# Altium schematic sheet grove_A9_Sensor.SchDoc(grove_A9_Sensor)
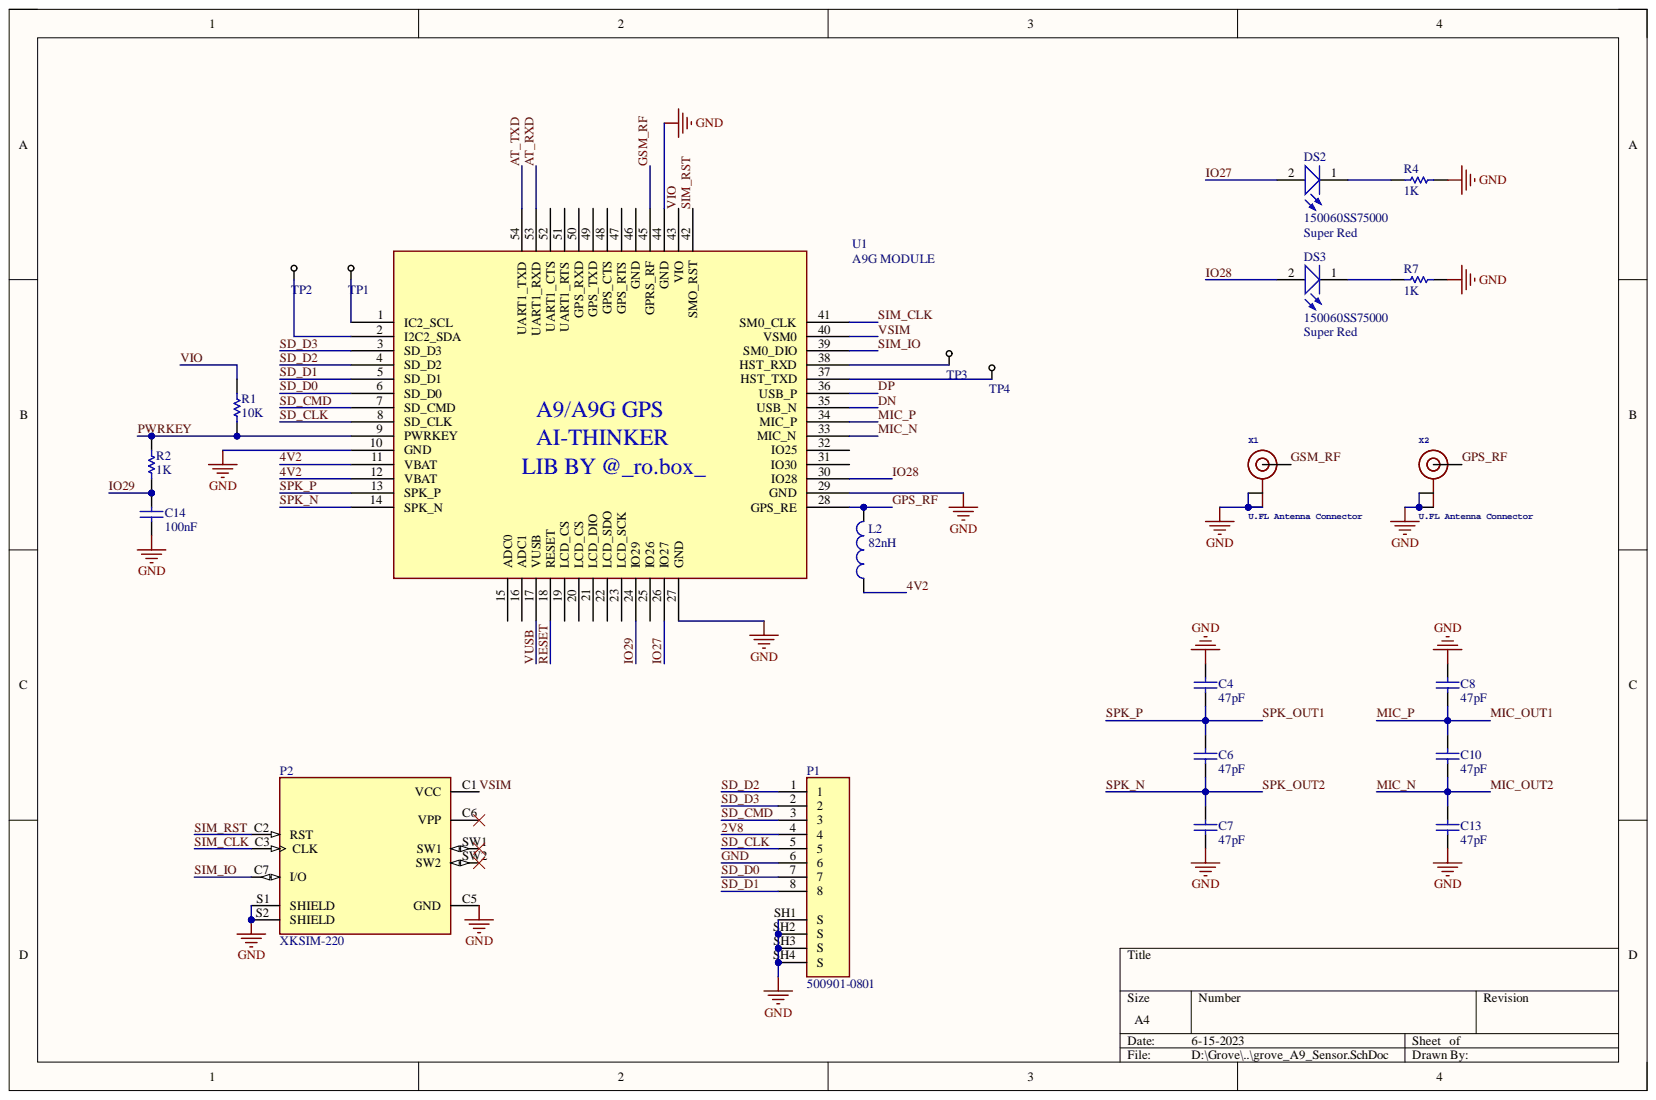
[diagram p0: grove_A9_Sensor.SchDoc]

## Parts
C4  47pF  [CAP0603]
C6  47pF  [CAP0603]
C7  47pF  [CAP0603]
C8  47pF  [CAP0603]
C10  47pF  [CAP0603]
C13  47pF  [CAP0603]
C14  100nF  [CAP0603]
DS2  150060SS75000  [WL-SMCW_0603]
DS3  150060SS75000  Emitting Color: Super Red  [WL-SMCW_0603]
L2  82nH  [IND0603]
P1  500901-0801  [MOLEX_500901-0801]
P2  XKSIM-220  [AMPHENOL_1010030682]
R1  10K  [RES0603]
R2  1K  [RES0603]
R4  1K  [RES0603]
R7  1K  [RES0603]
TP1  SCL  [Test Point SMD]  (value not drawn)
TP2  SDA  [Test Point SMD]  (value not drawn)
TP3  Test Point  [Test Point SMD]  (value not drawn)
TP4  Test Point  [Test Point SMD]  (value not drawn)
U1  A9G MODULE  [A9_FOOTPRINT]
X1  U.FL Antenna Connector  [U.FL]
X2  U.FL Antenna Connector  [U.FL]

## Nets
2V8: P1.4
4V2: L2.1 U1.11(VBAT) U1.12(VBAT)
AT_RXD: U1.53(UART1_RXD)
AT_TXD: U1.54(UART1_TXD)
DN: U1.35(USB_N)
DP: U1.36(USB_P)
GND: C4.2 C7.1 C8.2 C13.1 C14.1 P1.6 P1.SH1(S) P1.SH2(S) P1.SH3(S) P1.SH4(S) P2.C5(GND) P2.S1(SHIELD) P2.S2(SHIELD) R4.2 R7.2 U1.10(GND) U1.27(GND) U1.29(GND) U1.44(GND) X1.1 X1.2 X2.1 X2.2
GPS_RF: L2.2 U1.28(GPS_RE) X2.3
GSM_RF: U1.45(GPRS_RF) X1.3
IO27: DS2.2 U1.26(IO27)
IO28: DS3.2 U1.30(IO28)
IO29: C14.2 R2.1 U1.24(IO29)
MIC_N: C10.1 C13.2 U1.33(MIC_N)
MIC_OUT1: C8.1 C10.2 U1.34(MIC_P)
PWRKEY: R1.1 R2.2 U1.9(PWRKEY)
RESET: U1.18(RESET)
SD_CLK: P1.5 U1.8(SD_CLK)
SD_CMD: P1.3 U1.7(SD_CMD)
SD_D0: P1.7 U1.6(SD_D0)
SD_D1: P1.8 U1.5(SD_D1)
SD_D2: P1.1 U1.4(SD_D2)
SD_D3: P1.2 U1.3(SD_D3)
SIM_CLK: P2.C3(CLK) U1.41(SM0_CLK)
SIM_IO: P2.C7(I/O) U1.39(SM0_DIO)
SIM_RST: P2.C2(RST) U1.42(SMO_RST)
SPK_N: C6.1 C7.2 U1.14(SPK_N)
SPK_OUT1: C4.1 C6.2 U1.13(SPK_P)
VIO: R1.2 U1.43(VIO)
VSIM: P2.C1(VCC) U1.40(VSM0)
VUSB: U1.17(VUSB)
NetDS2_1: DS2.1 R4.1
NetDS3_1: DS3.1 R7.1
NetTP1_1: TP1.1 U1.1(IC2_SCL)
NetTP2_1: TP2.1 U1.2(I2C2_SDA)
NetTP3_1: TP3.1 U1.38(HST_RXD)
NetTP4_1: TP4.1 U1.37(HST_TXD)

Not connected: P2 C6(VPP) SW1 SW2; U1 15(ADC0) 16(ADC1) 19(LCD_CS) 20(LCD_CS) 21(LCD_DIO) 22(LCD_SDO) 23(LCD_SCK) 25(IO26) 31(IO30) 32(IO25) 46(GND) 47(GPS_RTS) 48(GPS_CTS) 49(GPS_TXD) 50(GPS_RXD) 51(UART1_RTS) 52(UART1_CTS)

## Library data of the parts
C4 C6-C8 C10 C13 = CAP SMD PACKAGE: TOTAL CAPACITOR SMD PACK; Desc.: CAP SMD TOTAL PACKAGES; Designer: Abbas Basereh; VALUE: 100nF; Component Kind: Standard; Data: 2017-3-10; Library Name: Altium.IntLib; Signal Integrity: CAP SMD
C14 = CAP SMD PACKAGE: CAPACITOR 0603; Desc.: CAP SMD TOTAL PACKAGES; Designer: Abbas Basereh; Component Kind: Standard; Data: 2017-3-10; Library Name: Altium.IntLib; Signal Integrity: CAP SMD; VALUE: 100nF
DS2 DS3 = 150060SS75000: WL-SMCW SMD Mono-color Chip LED Waterclear, size 0603, Super Red; Forward Voltage: 1.9 V; Peak Wavelength: 645 nm; Viewing Angle: 140°; Component Kind: Standard; ComponentLink1Description: Manufacturer; ComponentLink1URL: http://www.we-online.de; ComponentLink2Description: Datasheet; ComponentLink2URL: http://katalog.we-online.de/led/datasheet/150060SS75000.pdf; Library Name: LED SMT MonoColor.IntLib; Part Number: 150060SS75000; Published Date: Jan 2017; Size: 0603; Publisher: Wurth Elektronik
  DS2: Emitting Color: Super Red
L2 = INDUCTOR SMD PACKAGE: TOTAL INDUCTOR SMD PACK; Desc.: INDUCTOR  SMD TOTAL PACKAGES; Designer: Abbas Basereh; VALUE: 1u; Component Kind: Standard; Data: 2017-3-10; Library Name: Altium.IntLib; Signal Integrity: IND SMD
P1 = 500901-0801: 8 Position Card Connector Secure Digital - microSDâ„¢ Surface Mount, Right Angle Gold; MF: Molex; Availability: Unavailable; Component Kind: Standard; Library Name: 500901-0801.IntLib; MP: 500901-0801; Package: None; Price: None; SnapEDA_Link: https://www.snapeda.com/parts/500901-0801/Molex/view-part/48884/?ref=snap
P2 = 1010030682: 8 (6 + 2) Position Card Connector SIM Card Surface Mount, Right Angle Gold; MF: Amphenol; MP: 10-100306-82; Availability: In Stock; Check_prices: https://www.snapeda.com/parts/10-100306-82/Amphenol/view-part/?ref=eda; Component Kind: Standard; Library Name: 1010030682.IntLib; Package: None; Price: None; Purchase-URL: https://pricing.snapeda.com/search/part/10-100306-82/?ref=eda; SnapEDA_Link: https://www.snapeda.com/parts/10-100306-82/Amphenol/view-part/?ref=snap
R1 = RES SMD PACKAGE: RESISTOR - 0603; Desc.: RES SMD PAKAGES; Designer: Abbas Basereh; Component Kind: Standard; Data: 2017-3-10; Library Name: Altium.IntLib
R2 = RES SMD PACKAGE: TOTAL SMD RESISTOR PACK; Desc.: RES SMD PAKAGES; Designer: Abbas Basereh; Component Kind: Standard; Data: 2017-3-10; Library Name: Altium.IntLib
R4 R7 = RES SMD PACKAGE: RESISTOR 0603; Desc.: RES SMD PAKAGES; Designer: Abbas Basereh; Component Kind: Standard; Data: 2017-3-10; Library Name: Altium.IntLib
TP1 TP2 = Test Point: Component Kind: Standard; Description: Test Point; Library Name: Altium.IntLib
U1 = A9G_GPS_MODULE: Component Kind: Standard; Library Name: a9g_module.IntLib
X1 X2 = ANTENNA_U.FL: Component Kind: Standard; Library Name: adafruit.IntLib
TP3 TP4 = Test Point: Component Kind: Standard; Description: Test Point; Library Name: Altium.IntLib
